# Altium schematic sheet Oscilloscope.SchDoc
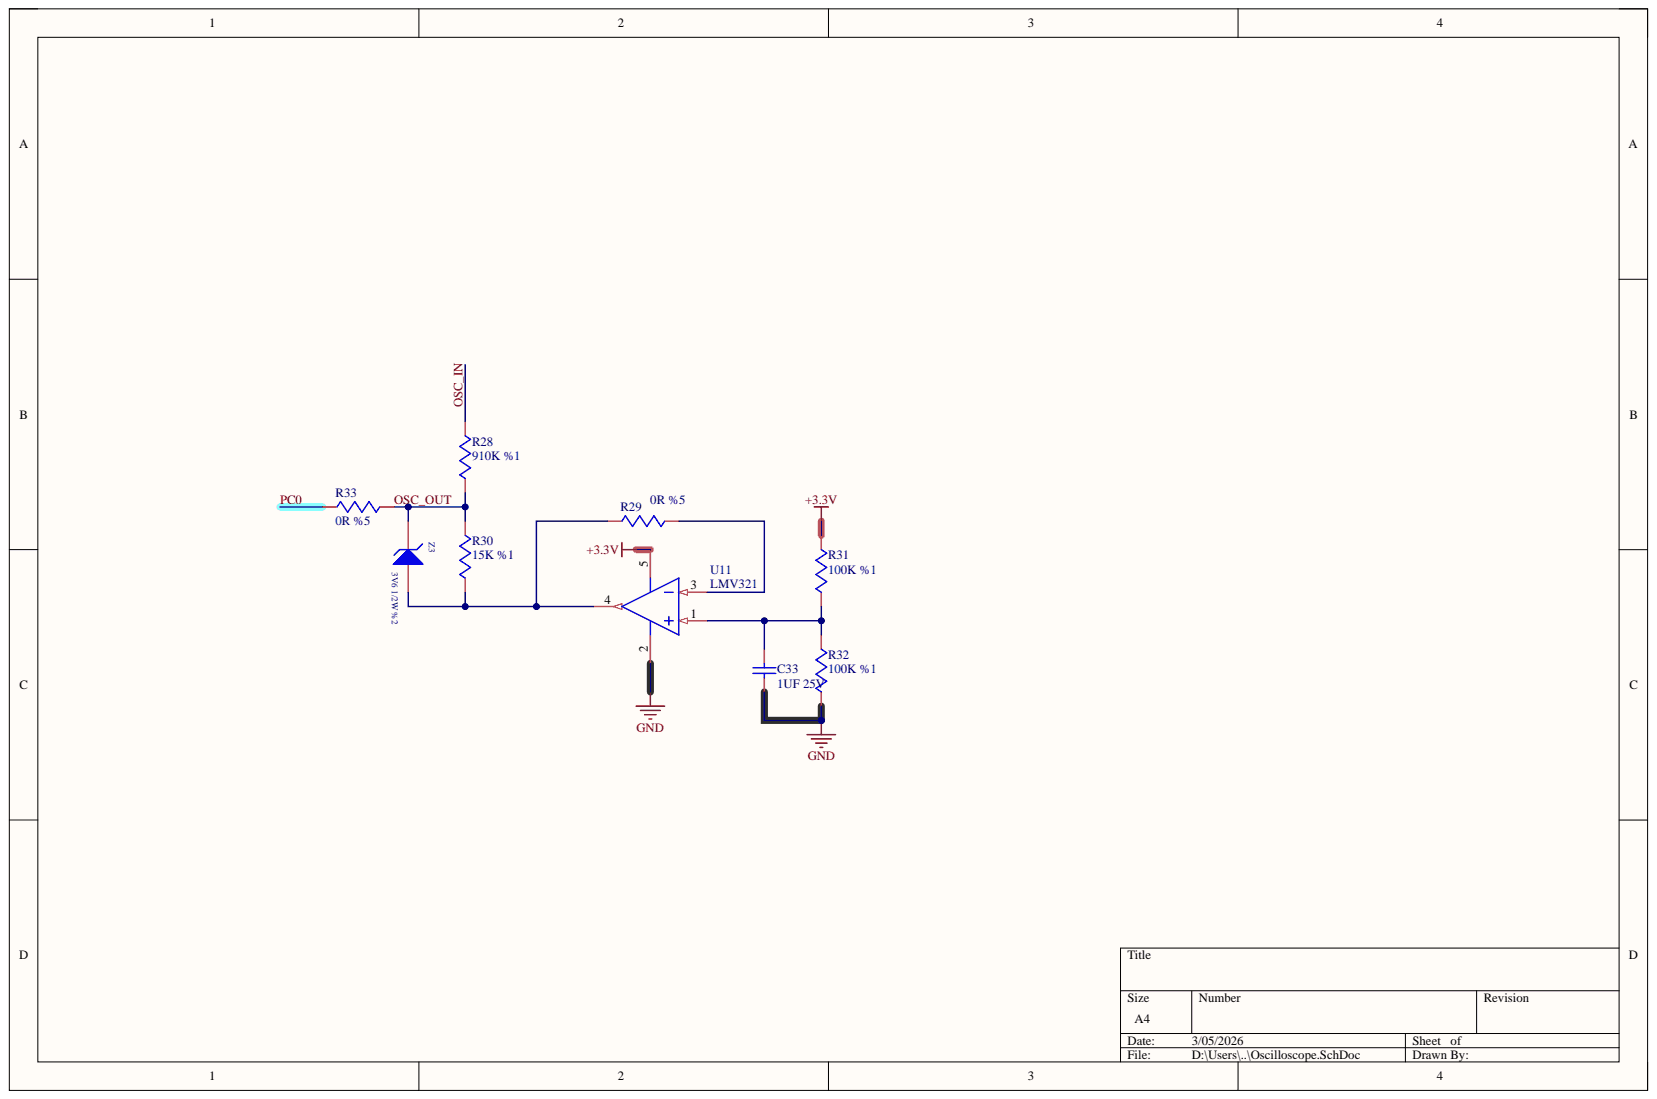
[diagram p0: Oscilloscope.SchDoc]

## Parts
C33  1UF 25V  [C0603]
R28  910K %1  [R0603]
R29  0R %5  [R0603]
R30  15K %1  [R0603]
R31  100K %1  [R0603]
R32  100K %1  [R0603]
R33  0R %5  [R0603]
U11  LMV321  [SOT23 5]
Z3  3V6 1/2W %2  [SOD123]

## Nets
+3.3V: R31.2 U11.5
GND: C33.1 R32.1 U11.2
OSC_IN: R28.2
OSC_OUT: R28.1 R30.2 R33.2 Z3.1
PC0: R33.1
NetC33_2: C33.2 R31.1 R32.2 U11.1
NetR29_1: R29.1 R30.1 U11.4 Z3.2
NetR29_2: R29.2 U11.3

## Library data of the parts
C33 = C0603 1UF 25V %10X7R: Database Table Name: Capacitor SMD; Malzeme Tanımı: CAP 0603 1UF 25V %10 X7R; SapStokKodu: 1200920567; Siparis Kodu: VJ0603Y105KXXCW1BC; Uretici: SAMSUNG; Component Kind: Standard; Part Number: C0603 1UF 25V %10X7R; Value: 1UF 25V; Library Name: OTL.DbLib
R28 = R0603 910K %1 1/10W: Database Table Name: Resistor SMD; Malzeme Tanımı: RES 0603 910K %1 1/10W; SapStokKodu: 1200970965; Siparis Kodu: ERJ3EKF9103V; Component Kind: Standard; Part Number: R0603 910K %1 1/10W; Value: 910K %1; Library Name: OTL.DbLib
R29 R33 = R0603 0R %5 1/10W: Database Table Name: Resistor SMD; Malzeme Tanımı: RES 0603 0R %5 1/10W; SapStokKodu: 1200970528; Siparis Kodu: MCR03EZPJ000; Component Kind: Standard; Part Number: R0603 0R %5 1/10W; Value: 0R %5; Library Name: OTL.DbLib
R30 = R0603 15K %1 1/10W: Database Table Name: Resistor SMD; Malzeme Tanımı: RES 0603 15K %1 1/10W; SapStokKodu: 1200970843; Siparis Kodu: FCF03FT1502; Component Kind: Standard; Part Number: R0603 15K %1 1/10W; Value: 15K %1; Library Name: OTL.DbLib
R31 R32 = R0603 100K %1 1/10W: Database Table Name: Resistor SMD; Malzeme Tanımı: RES 0603 100K %1 1/10W; SapStokKodu: 1200970803; Siparis Kodu: FCF03FT1003; Component Kind: Standard; Part Number: R0603 100K %1 1/10W; Value: 100K %1; Library Name: OTL.DbLib
U11 = LMV321 SOT23-5_: Database Table Name: IC Analog; Malzeme Tanımı: IC OPAMP SM LMV321AS5X JANUS; SAPStokKodu: 1200980663; Component Kind: Standard; Part Number: LMV321 SOT23-5_; Siparis Kodu: LMV321; Value: LMV321; Library Name: OTL.DbLib
Z3 = MMSZ52 3V6 1/2W %2: Database Table Name: Diode Zener; Malzeme Tanımı: DIODE ZENER SM 3V6 1/2W %2; SAPStokKodu: 1200980646; Siparis Kodu: BZT52B3V6-E3-08; Component Kind: Standard; Part Number: MMSZ52 3V6 1/2W %2; Value: 3V6 1/2W %2; Library Name: OTL.DbLib
